# Altium schematic sheet InputConnector.SchDoc
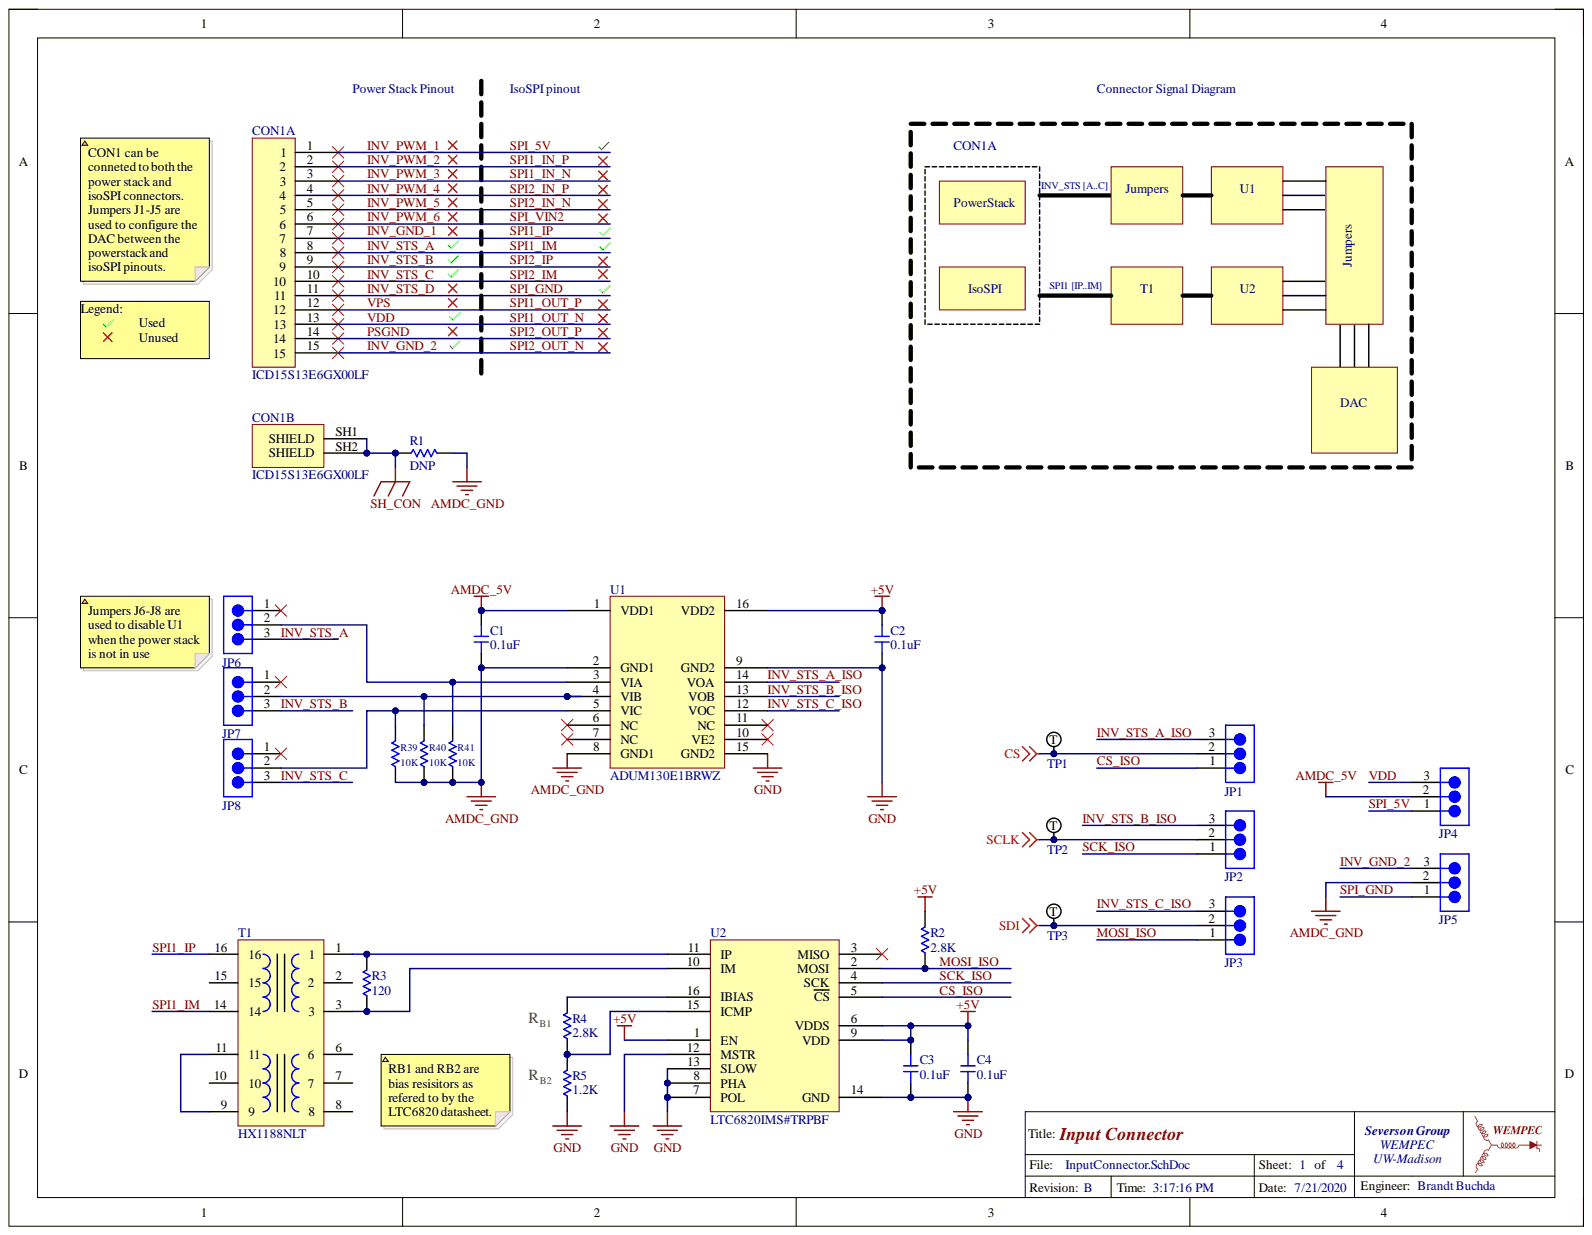
[diagram p0: InputConnector.SchDoc]

## Parts
C1  value 0.1uF  [0603-CAP]  (hidden comment: CC0603KRX7R8BB104)
C2  value 0.1uF  [0603-CAP]  (hidden comment: CC0603KRX7R8BB104)
C3  value 0.1uF  [0603-CAP]  (hidden comment: CC0603KRX7R8BB104)
C4  value 0.1uF  [0603-CAP]  (hidden comment: CC0603KRX7R8BB104)
CON1  ICD15S13E6GX00LF  [ICD15S13E6GX00LF]  (parts A,B)
JP1  TSW-103-07-F-S  [PIN_HEADER_1X3]  (value not drawn)
JP2  TSW-103-07-F-S  [PIN_HEADER_1X3]  (value not drawn)
JP3  TSW-103-07-F-S  [PIN_HEADER_1X3]  (value not drawn)
JP4  TSW-103-07-F-S  [PIN_HEADER_1X3]  (value not drawn)
JP5  TSW-103-07-F-S  [PIN_HEADER_1X3]  (value not drawn)
JP6  TSW-103-07-F-S  [PIN_HEADER_1X3]  (value not drawn)
JP7  TSW-103-07-F-S  [PIN_HEADER_1X3]  (value not drawn)
JP8  TSW-103-07-F-S  [PIN_HEADER_1X3]  (value not drawn)
R1  value DNP  [0603-RES]  (hidden comment: Resistor)  (no BOM)
R2  value 2.8K  [0603-RES]  (hidden comment: RC0603FR-072K8L)
R3  value 120  [0603-RES]  (hidden comment: RC0603FR-07120RL)
R4  value 2.8K  [0603-RES]  (hidden comment: RC0603FR-072K8L)
R5  value 1.2K  [0603-RES]  (hidden comment: RC0603FR-071K2L)
R39  value 10K  [0603-RES]  (hidden comment: ERJ-PB3B1002V)
R40  value 10K  [0603-RES]  (hidden comment: ERJ-PB3B1002V)
R41  value 10K  [0603-RES]  (hidden comment: ERJ-PB3B1002V)
T1  HX1188NLT  [HX1188NLT]
TP1  Test Point  (value not drawn)
TP2  Test Point  (value not drawn)
TP3  Test Point  (value not drawn)
U1  ADUM130E1BRWZ  [SOIC127P1030X265-16N]
U2  LTC6820IMS#TRPBF  [TSSOP50P490X110-16N]

## Nets
+5V: C2.2 C3.2 C4.2 R2.2 U1.16(VDD2) U2.1(EN) U2.6(VDDS) U2.9(VDD)
AMDC_5V: C1.2 JP4.2 U1.1(VDD1)
AMDC_GND: C1.1 JP5.2 R1.2 R39.1 R40.1 R41.1 U1.2(GND1) U1.8(GND1)
CS: JP1.2 TP1.1
GND: C2.1 C3.1 C4.1 R5.1 U1.9(GND2) U1.15(GND2) U2.7(POL) U2.8(PHA) U2.12(MSTR) U2.13(SLOW) U2.14(GND)
SCLK: JP2.2 TP2.1
SDI: JP3.2 TP3.1
SH_CON: CON1.SH1(SHIELD) CON1.SH2(SHIELD) R1.1
CS_ISO: JP1.1 U2.5(CS)
INV_GND_1: CON1.7 T1.16
INV_GND_2: CON1.15 JP5.3
INV_PWM_1: CON1.1 JP4.1
INV_PWM_2: CON1.2
INV_PWM_3: CON1.3
INV_PWM_4: CON1.4
INV_PWM_5: CON1.5
INV_PWM_6: CON1.6
INV_STS_A: CON1.8 JP6.3 T1.14
INV_STS_A_ISO: JP1.3 U1.14(VOA)
INV_STS_B: CON1.9 JP7.3
INV_STS_B_ISO: JP2.3 U1.13(VOB)
INV_STS_C: CON1.10 JP8.3
INV_STS_C_ISO: JP3.3 U1.12(VOC)
INV_STS_D: CON1.11 JP5.1
MOSI_ISO: JP3.1 R2.1 U2.2(MOSI)
PSGND: CON1.14
SCK_ISO: JP2.1 U2.4(SCK)
SPI1_OUT_N: CON1.13 JP4.3
SPI1_OUT_P: CON1.12
NetJP6_2: JP6.2 R41.2 U1.3(VIA)
NetJP7_2: JP7.2 R40.2 U1.4(VIB)
NetJP8_2: JP8.2 R39.2 U1.5(VIC)
NetR3_1: R3.1 T1.3 U2.10(IM)
NetR3_2: R3.2 T1.1 U2.11(IP)
NetR4_1: R4.1 R5.2 U2.15(ICMP)
NetR4_2: R4.2 U2.16(IBIAS)
NetT1_9: T1.9 T1.11

Not connected: JP6 1; JP7 1; JP8 1; T1 2 6 7 8 10 15; U1 6(NC) 7(NC) 10(VE2) 11(NC); U2 3(MISO)

## Library data of the parts
C1-C4 = Capacitor 0.1uF: 0.1µF ±10% 25V Ceramic Capacitor X7R 0603 (1608 Metric); Component Kind: Standard; Simulation: CAP; Supplier 1: Digi-Key; Supplier Part Number 1: 311-1341-1-ND; Library Name: DAC.SchLib
CON1 = ICD15S13E6GX00LF: 15 Position D-Sub, High Density Receptacle, Female Sockets Connector; Component Kind: Standard; Supplier 1: Digi-Key; Supplier Part Number 1: 609-2801-ND; Library Name: DAC.SchLib
JP1-JP8 = TSW-103-07-F-S: Connector Header Through Hole 3 position 0.100" (2.54mm); Component Kind: Standard; Supplier 1: Digi-Key; Supplier Part Number 1: SAM8995-ND; Library Name: DAC.SchLib
R1 = Resistor: DNP; Simulation: RES; Supplier 1: Digi-Key; Library Name: DAC.SchLib
R2 R4 = Resistor 2.8K: 2.8 kOhms ±1% 0.1W, 1/10W Chip Resistor 0603 (1608 Metric) Moisture Resistant Thick Film; Component Kind: Standard; Simulation: RES; Supplier 1: Digi-Key; Supplier Part Number 1: 311-2.80KHRCT-ND; Library Name: DAC.SchLib
R3 = Resistor 120: 120 Ohms ±1% 0.1W, 1/10W Chip Resistor 0603 (1608 Metric) Moisture Resistant Thick Film; Component Kind: Standard; Simulation: RES; Supplier 1: Digi-Key; Supplier Part Number 1: 311-120HRCT-ND; Library Name: DAC.SchLib
R5 = Resistor 1.2K: 1.2 kOhms ±1% 0.1W, 1/10W Chip Resistor 0603 (1608 Metric) Moisture Resistant Thick Film; Component Kind: Standard; Simulation: RES; Supplier 1: Digi-Key; Supplier Part Number 1: 311-1.20KHRCT-ND; Library Name: DAC.SchLib
R39-R41 = Resistor 10K: 10 kOhms ±0.1% 0.2W, 1/5W Chip Resistor 0603 (1608 Metric) Automotive AEC-Q200, Pulse Withstanding Thick Film; Component Kind: Standard; Simulation: RES; Supplier 1: Digi-Key; Supplier Part Number 1: P20086CT-ND; Library Name: DAC.SchLib
T1 = HX1188NLT: XFRMR MAGNETIC 1PORT 1:1 10/100; Component Kind: Standard; Supplier 1: Digi-Key; Supplier Part Number 1: 553-1536-1-ND; Library Name: AMDC.SchLib
TP1-TP3 = Test Point: Material - Insulation: No Insulation; Category: Test and Measurement; Color: Natural; Component Kind: Standard; ComponentLink1Description: http://www.te.com/commerce/DocumentDelivery/DDEController?Action=srchrtrv&DocNm=1773266&DocType=DS&DocLang=English; ComponentLink1URL: http://www.te.com/commerce/DocumentDelivery/DDEController?Action=srchrtrv&DocNm=1773266&DocType=DS&DocLang=English; Description: Test Point; Material - Body: -; Mounting Type: Surface Mount; Packaging: Cut Tape (CT); Part Status: Active; Plating: -; Pricing 1: 1=0.22, 10=0.206, 25=0.1756, 50=0.1536, 100=0.1426, 250=0.12616, 500=0.12068, 1000=0.09874 (USD); RoHS: RoHS Compliant; Size / Dimension: 0.063" x 0.031" x 0.045" (1.60mm x 0.80mm x 1.15mm); Stock 1: 136646; Supplier 1: Digi-Key; Supplier Part Number 1: A106145CT-ND; Type: PC Test Point; Library Name: DAC.SchLib
U1 = ADUM130E1BRWZ: General Purpose Digital Isolator 3750Vrms 3 Channel 150Mbps 75kV/µs CMTI 16-SOIC (0.295", 7.50mm Width); Component Kind: Standard; Supplier 1: Digi-Key; Supplier Part Number 1: ADUM130E1BRWZ-ND; Library Name: DAC.SchLib
U2 = LTC6820IMS#TRPBF: IC INTERFACE SPECIALIZED 16MSOP; Component Kind: Standard; Supplier 1: Digi-Key; Supplier Part Number 1: LTC6820IMS#TRPBFCT-ND; Library Name: AMDC.SchLib
